# Altium schematic sheet Sheet2.SchDoc(Sheet2)
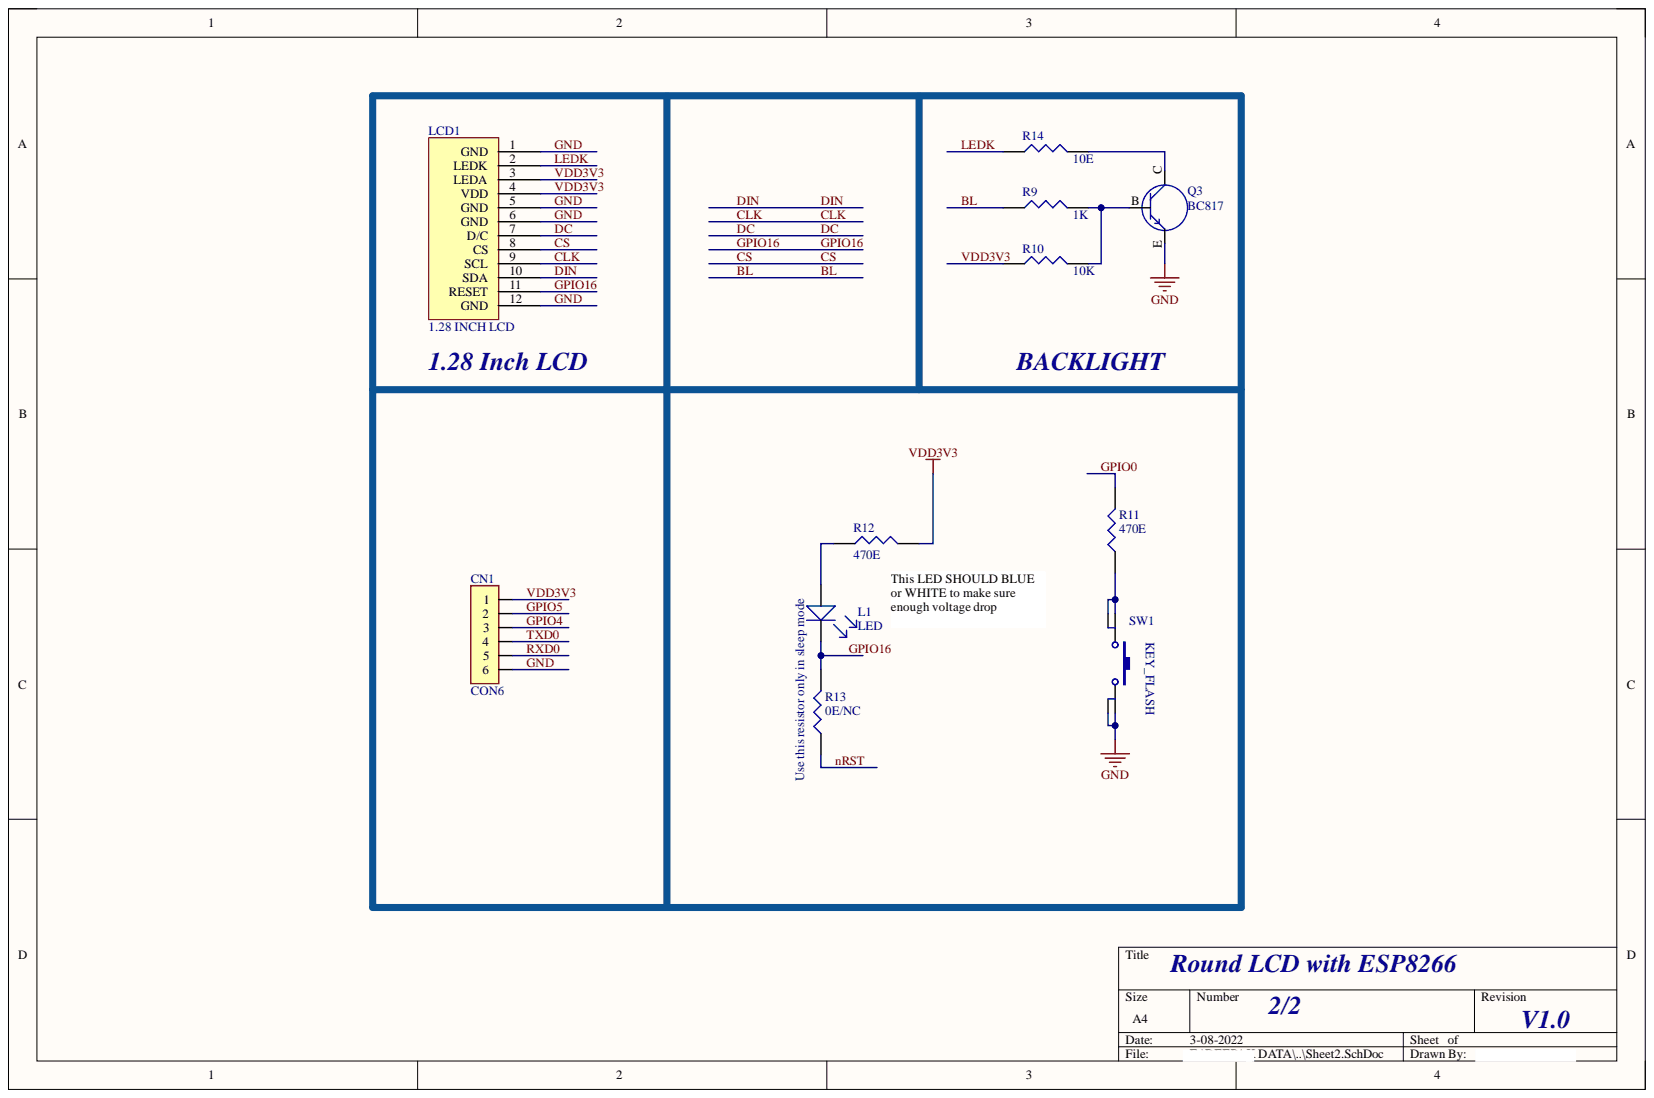
[diagram p0: Sheet2.SchDoc]

## Parts
CN1  CON6  [CON6_BJ]
L1  LED  [LED_0603_BLUE]
LCD1  1.28 INCH LCD  [1.28 INCH LCD C]
Q3  BC817  [547_SOT23]
R9  1K  [0603]
R10  10K  [0603]
R11  470E  [0603]
R12  470E  [0603]
R13  0E/NC  [0603]
R14  10E  [0603]
SW1  TACTILE_SWITCH  [SKRPACE010]  (value not drawn)

## Nets
BL: R9.1
CLK: LCD1.9(SCL)
CS: LCD1.8(CS)
DC: LCD1.7(D/C)
DIN: LCD1.10(SDA)
GND: CN1.6 LCD1.1(GND) LCD1.5(GND) LCD1.6(GND) LCD1.12(GND) Q3.E SW1.3 SW1.4
GPIO0: R11.2
GPIO4: CN1.3
GPIO5: CN1.2
GPIO16: L1.K LCD1.11(RESET) R13.2
LEDK: LCD1.2(LEDK) R14.1
nRST: R13.1
RXD0: CN1.5
TXD0: CN1.4
VDD3V3: CN1.1 LCD1.3(LEDA) LCD1.4(VDD) R10.1 R12.2
NetL1_A: L1.A R12.1
NetQ3_B: Q3.B R9.2 R10.2
NetQ3_C: Q3.C R14.2
NetR11_1: R11.1 SW1.1 SW1.2

## Library data of the parts
LCD1 = LCD ROUND: 1.28 INCH ROUND LCD GC9A01; Component Kind: Standard; Library Name: dxp_pasl_lib.IntLib
Q3 = TRANSISTER_NPN: Component Kind: Standard; Library Name: dxp_pasl_lib.IntLib
R9-R14 = RES: Component Kind: Standard; Library Name: dxp_pasl_lib.IntLib
CN1 = CON6: Component Kind: Standard; Library Name: dxp_pasl_lib.IntLib
L1 = LED: Component Kind: Standard; Library Name: dxp_pasl_lib.IntLib
SW1 = TACTILE_SWITCH: Component Kind: Standard; Library Name: dxp_pasl_lib.IntLib
